# Altium schematic sheet eBox_LED.SchDoc
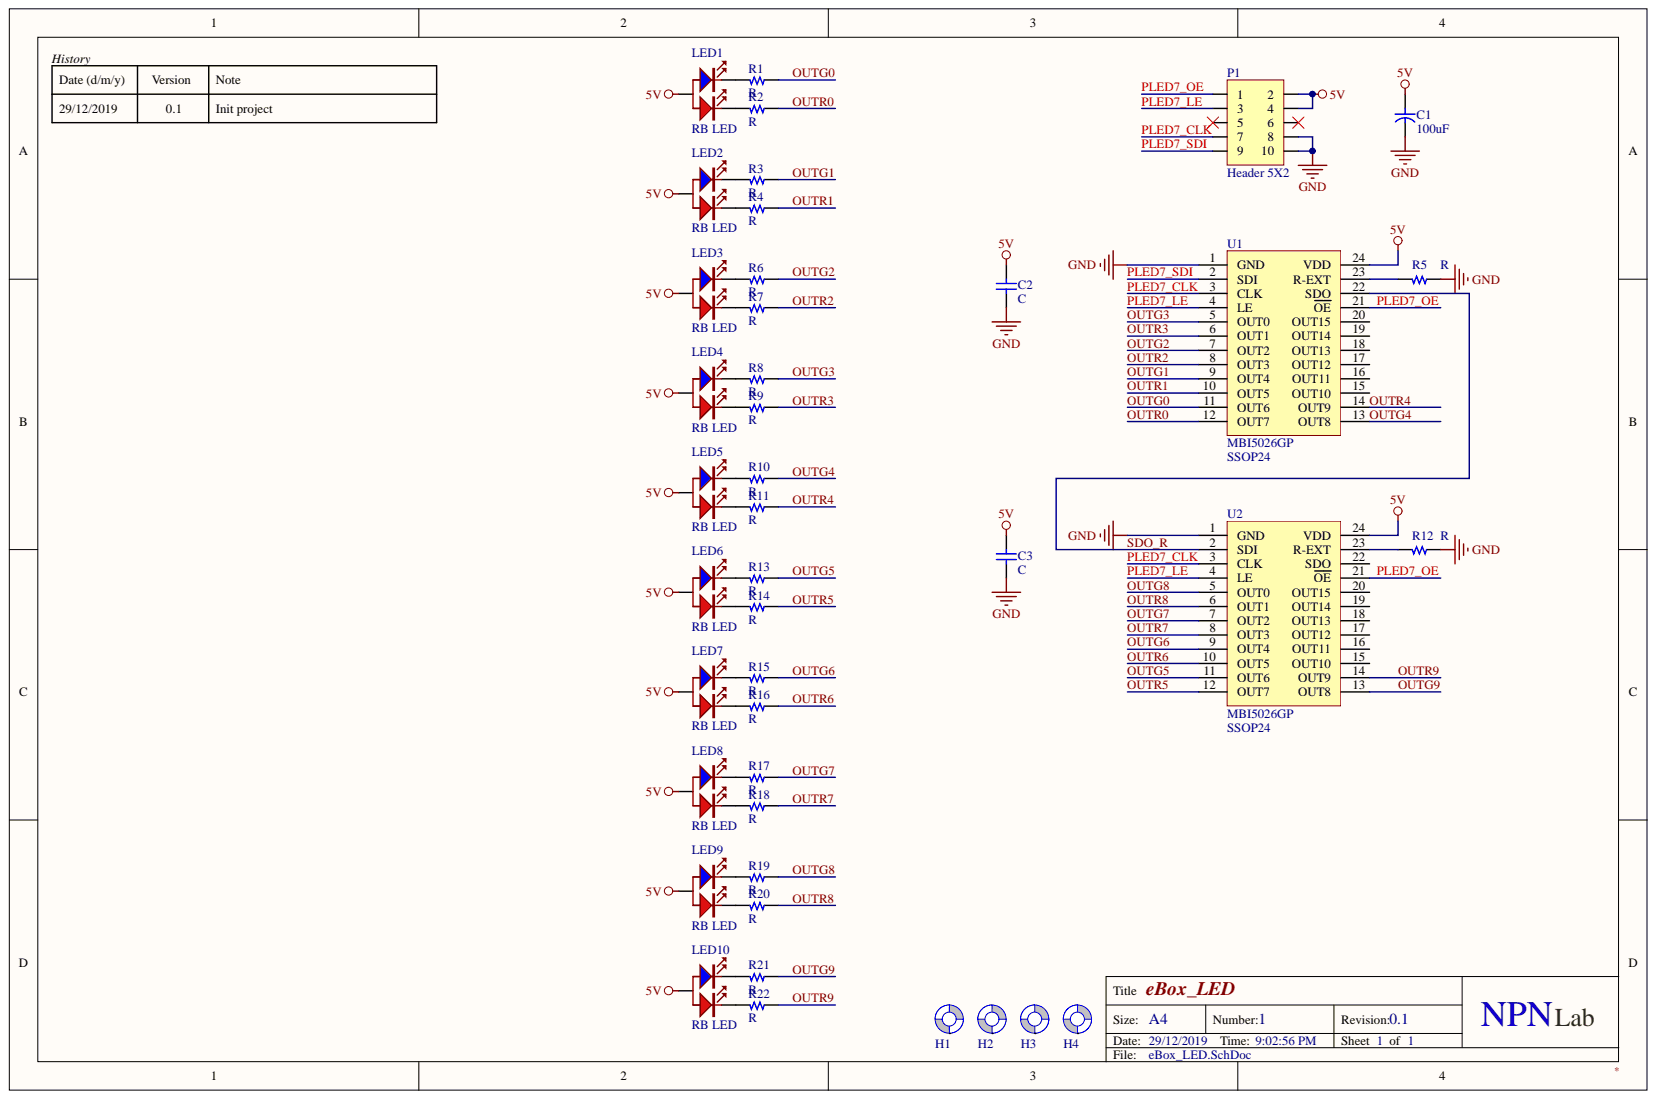
[diagram p0: eBox_LED.SchDoc]

## Parts
C1  100uF  [C SMD TAN 1210 (B)]
C2  C  [C 0805]
C3  C  [C 0805]
H1  Screw Hole  [SCREW/3.2/7.0/M]  (value not drawn)  (no BOM)
H2  Screw Hole  [SCREW/3.2/7.0/M]  (value not drawn)  (no BOM)
H3  Screw Hole  [SCREW/3.2/7.0/M]  (value not drawn)  (no BOM)
H4  Screw Hole  [SCREW/3.2/7.0/M]  (value not drawn)  (no BOM)
LED1  RB LED  [LED-BICOLOR-3MM]
LED2  RB LED  [LED-BICOLOR-3MM]
LED3  RB LED  [LED-BICOLOR-3MM]
LED4  RB LED  [LED-BICOLOR-3MM]
LED5  RB LED  [LED-BICOLOR-3MM]
LED6  RB LED  [LED-BICOLOR-3MM]
LED7  RB LED  [LED-BICOLOR-3MM]
LED8  RB LED  [LED-BICOLOR-3MM]
LED9  RB LED  [LED-BICOLOR-3MM]
LED10  RB LED  [LED-BICOLOR-3MM]
P1  Header 5X2  [HDR/Header/10/DL/BOX]
R1  R  [R 0603]
R2  R  [R 0603]
R3  R  [R 0603]
R4  R  [R 0603]
R5  R  [R 0805]
R6  R  [R 0603]
R7  R  [R 0603]
R8  R  [R 0603]
R9  R  [R 0603]
R10  R  [R 0603]
R11  R  [R 0603]
R12  R  [R 0805]
R13  R  [R 0603]
R14  R  [R 0603]
R15  R  [R 0603]
R16  R  [R 0603]
R17  R  [R 0603]
R18  R  [R 0603]
R19  R  [R 0603]
R20  R  [R 0603]
R21  R  [R 0603]
R22  R  [R 0603]
U1  MBI5026GP  PACKAGE: SSOP24  [SSOP24/MBI5026GP]
U2  MBI5026GP  PACKAGE: SSOP24  [SSOP24/MBI5026GP]

## Nets
5V: C1.1 C2.1 C3.1 LED1.2 LED2.2 LED3.2 LED4.2 LED5.2 LED6.2 LED7.2 LED8.2 LED9.2 LED10.2 P1.2 P1.4 U1.24(VDD) U2.24(VDD)
GND: C1.2 C2.2 C3.2 P1.8 P1.10 R5.1 R12.1 U1.1(GND) U2.1(GND)
OUTG0: R1.1 U1.11(OUT6)
OUTG1: R3.1 U1.9(OUT4)
OUTG2: R6.1 U1.7(OUT2)
OUTG3: R8.1 U1.5(OUT0)
OUTG4: R10.1 U1.13(OUT8)
OUTG5: R13.1 U2.11(OUT6)
OUTG6: R15.1 U2.9(OUT4)
OUTG7: R17.1 U2.7(OUT2)
OUTG8: R19.1 U2.5(OUT0)
OUTG9: R21.1 U2.13(OUT8)
OUTR0: R2.1 U1.12(OUT7)
OUTR1: R4.1 U1.10(OUT5)
OUTR2: R7.1 U1.8(OUT3)
OUTR3: R9.1 U1.6(OUT1)
OUTR4: R11.1 U1.14(OUT9)
OUTR5: R14.1 U2.12(OUT7)
OUTR6: R16.1 U2.10(OUT5)
OUTR7: R18.1 U2.8(OUT3)
OUTR8: R20.1 U2.6(OUT1)
OUTR9: R22.1 U2.14(OUT9)
PLED7_CLK: P1.7 U1.3(CLK) U2.3(CLK)
PLED7_LE: P1.3 U1.4(LE) U2.4(LE)
PLED7_OE: P1.1 U1.21(OE) U2.21(OE)
PLED7_SDI: P1.9 U1.2(SDI)
SDO_R: U1.22(SDO) U2.2(SDI)
NetLED1_1: LED1.1 R2.2
NetLED1_3: LED1.3 R1.2
NetLED2_1: LED2.1 R4.2
NetLED2_3: LED2.3 R3.2
NetLED3_1: LED3.1 R7.2
NetLED3_3: LED3.3 R6.2
NetLED4_1: LED4.1 R9.2
NetLED4_3: LED4.3 R8.2
NetLED5_1: LED5.1 R11.2
NetLED5_3: LED5.3 R10.2
NetLED6_1: LED6.1 R14.2
NetLED6_3: LED6.3 R13.2
NetLED7_1: LED7.1 R16.2
NetLED7_3: LED7.3 R15.2
NetLED8_1: LED8.1 R18.2
NetLED8_3: LED8.3 R17.2
NetLED9_1: LED9.1 R20.2
NetLED9_3: LED9.3 R19.2
NetLED10_1: LED10.1 R22.2
NetLED10_3: LED10.3 R21.2
NetR5_2: R5.2 U1.23(R-EXT)
NetR12_2: R12.2 U2.23(R-EXT)

Not connected: H1 1; H2 1; H3 1; H4 1; P1 5 6; U1 15(OUT10) 16(OUT11) 17(OUT12) 18(OUT13) 19(OUT14) 20(OUT15); U2 15(OUT10) 16(OUT11) 17(OUT12) 18(OUT13) 19(OUT14) 20(OUT15) 22(SDO)

## Library data of the parts
C1 = Capacitor: Capacitor pol; Component Kind: Standard; Library Name: chipfc_altium_libs.IntLib
C2 C3 = Capacitor NP: Capacitor non-pol; Component Kind: Standard; Library Name: chipfc_altium_libs.IntLib
LED1-LED10 = LED 2-color-A: Bicolor RB 3mm LED; Component Kind: Standard; Library Name: chipfc_altium_libs.IntLib
P1 = Header 5X2: Header, 5-Pin, Dual row; Component Kind: Standard; Library Name: chipfc_altium_libs.IntLib
R1-R22 = Resistor: Component Kind: Standard; Description: Resistor; Library Name: chipfc_altium_libs.IntLib
U1 U2 = MBI5026GP: 16-bit Constant Current LED Sink Driver; PART NO: MBI5026GF; BRAND: Macroblock; Component Kind: Standard; Library Name: chipfc_altium_libs.IntLib; TYPE: SMD
H1-H4 = Screw Hole: Description: Screw Hole; Library Name: chipfc_altium_libs_extras.IntLib
